# Altium schematic sheet BSides_2026_plugin.SchDoc(BSides_2026_plugin)
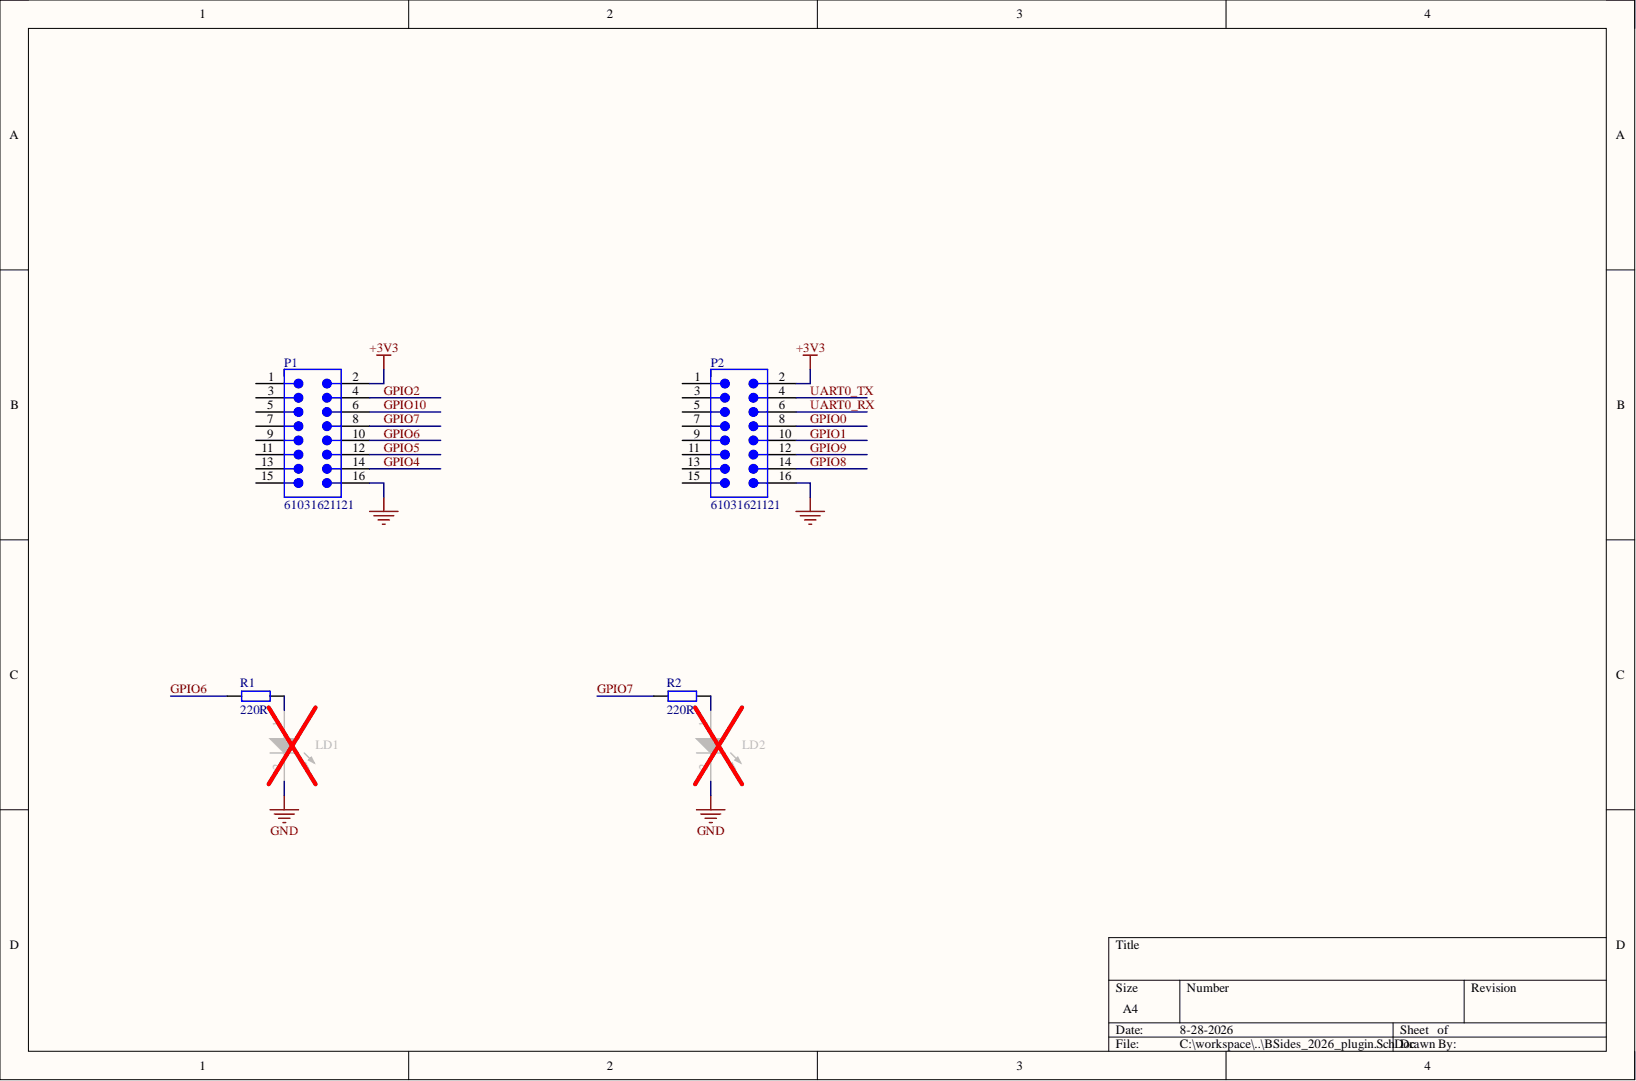
[diagram p0: BSides_2026_plugin.SchDoc]

## Parts
LD1  151031VS06000  [WL-TMRC_D3.95H5.3]  (value not drawn)
LD2  151031VS06000  [WL-TMRC_D3.95H5.3]  (value not drawn)
P1  61031621121  [61031621121]
P2  61031621121  [61031621121]
R1  220R  [RES 0805 [2012]]
R2  220R  [RES 0805 [2012]]

## Nets
+3V3: P1.2 P2.2
GND: LD1.2 LD2.2 P1.16 P2.16
GPIO0: P2.8
GPIO1: P2.10
GPIO2: P1.4
GPIO4: P1.14
GPIO5: P1.12
GPIO6: P1.10 R1.2
GPIO7: P1.8 R2.2
GPIO8: P2.14
GPIO9: P2.12
GPIO10: P1.6
UART0_RX: P2.6
UART0_TX: P2.4
NetLD1_1: LD1.1 R1.1
NetLD2_1: LD2.1 R2.1

Not connected: P1 1 3 5 7 9 11 13 15; P2 1 3 5 7 9 11 13 15

## Library data of the parts
LD1 LD2 = CMP-1488-00004-2: NexarPartId: 29717805; Color: Green; Dominant Wavelength: 568nm; Forward Current: 100mA; Forward Voltage: 2.2V; Lens Size: 3mm; Lens Style: Circular, Diffused, Round; Luminous Intensity: 30mcd; Max Reverse Voltage (DC): 5V; Peak Wavelength: 560nm; Test Current: 20mA; Viewing Angle: 60°; Case/Package: Radial; Component Kind: Standard; Height: 5.3mm; Lead Free: Lead Free; Length: 3mm; Library Name: Altium Content Vault; Max Operating Temperature: 85°C; Min Operating Temperature: -40°C; Mount: Through Hole; Packaging: Bulk; REACH SVHC: Unknown; RoHS: Compliant; Wavelength: 568nm; Width: 3mm
P1 P2 = CMP-1502-01056-4: SMT Vertical Pin Header WR-PHD, Pitch 2.54 mm, Dual Row, 16 pins; Connector Type: Male Pin; Contact Resistance: 20mΩ; Current Rating: 3A; Gender: Male; Number of Contacts: 16; Operating Supply Voltage: 250V; Pitch: 2.54mm; System: Connector; Color: Black; Component Kind: Standard; Contact Material: Gold; Contact Mating Length: 5.9944mm; Contact Plating: Gold; Height: 10mm; LCSC: C55213919; Lead Pitch: 2.54mm; Library Name: Altium Content Vault; Max Operating Temperature: 105°C; Min Operating Temperature: -40°C; Mount: Surface Mount; Number of Pins: 16; Number of Positions: 16; Number of Rows: 2; Orientation: Vertical; Packaging: Tape and Reel; RoHS: Compliant; Row Spacing: 2.54mm; Termination: Solder; Voltage Rating: 250V
R1 R2 = RES: RES 0805 220R; Manufacturer: Uniroyal; Manufacturer Part Number: 0805W8F2200T5E; Component Kind: Standard; LCSC: C17557; Library Name: BSides_2024.SCHLIB
